# Altium schematic sheet COMMUNICATION.SchDoc
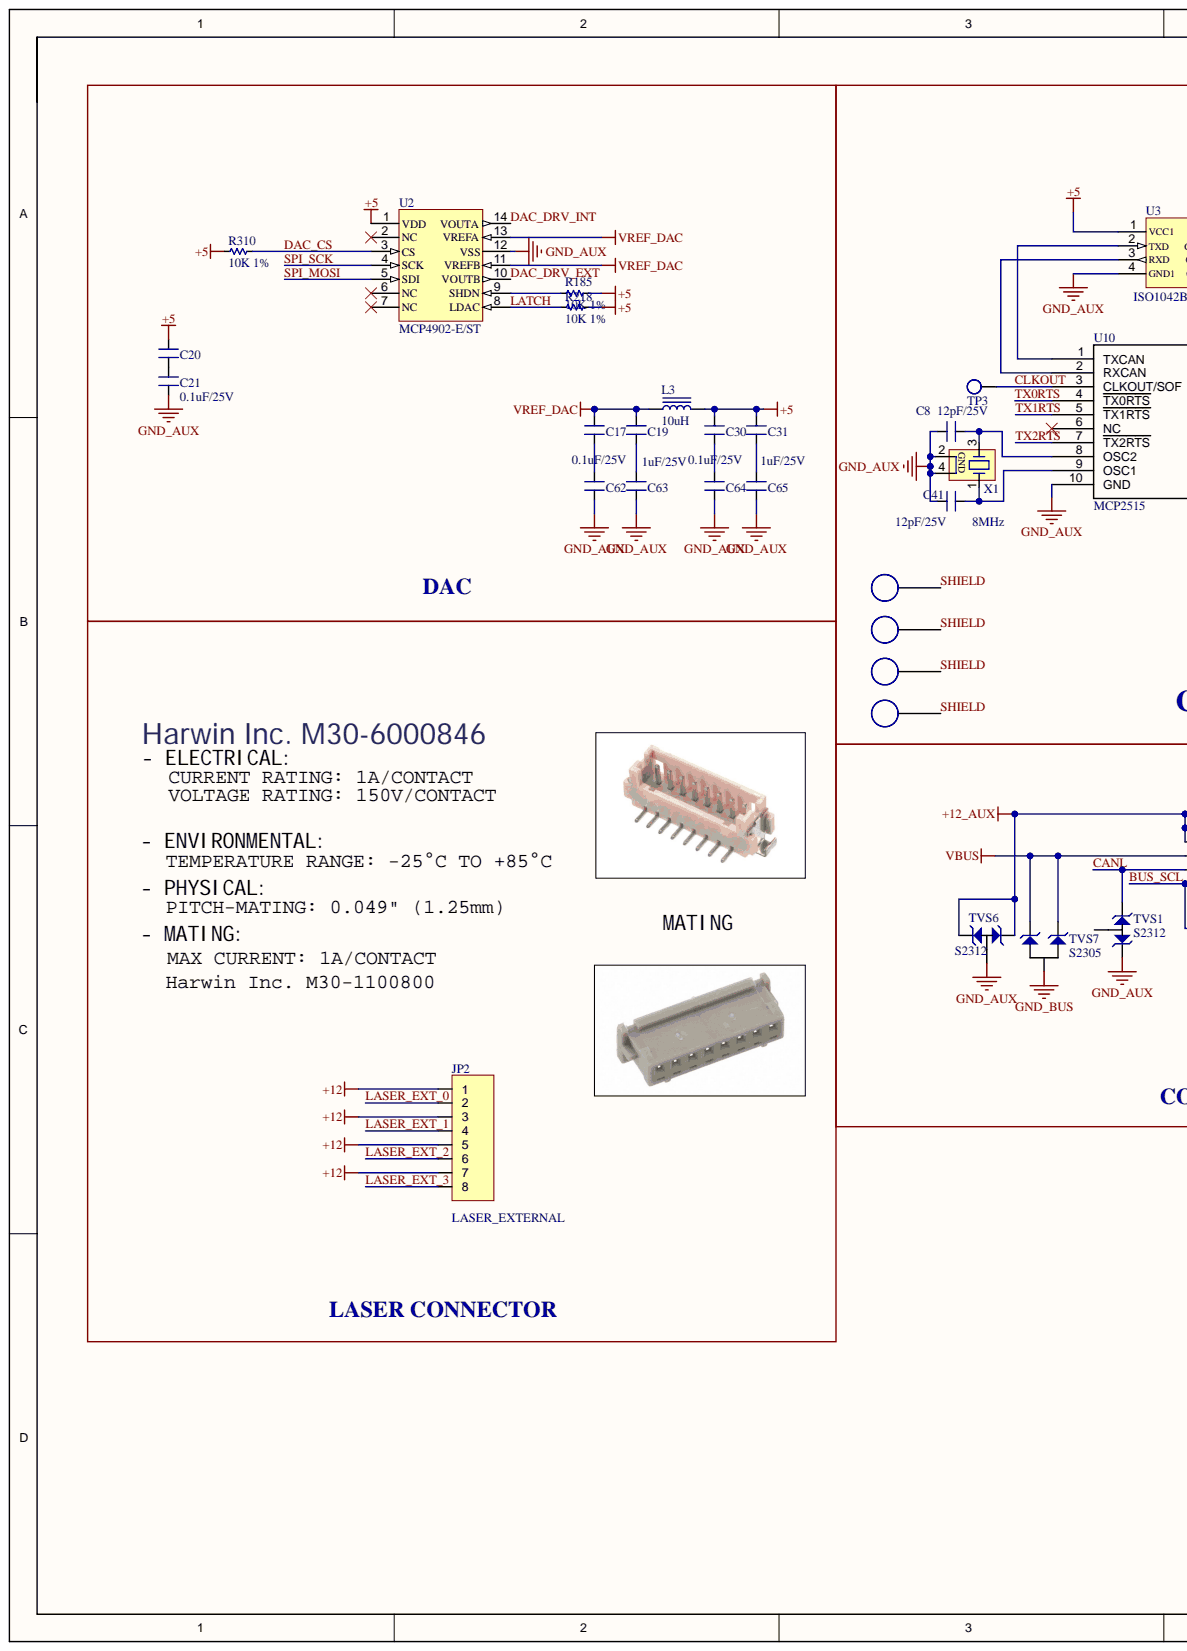
[diagram p0: COMMUNICATION.SchDoc, left]
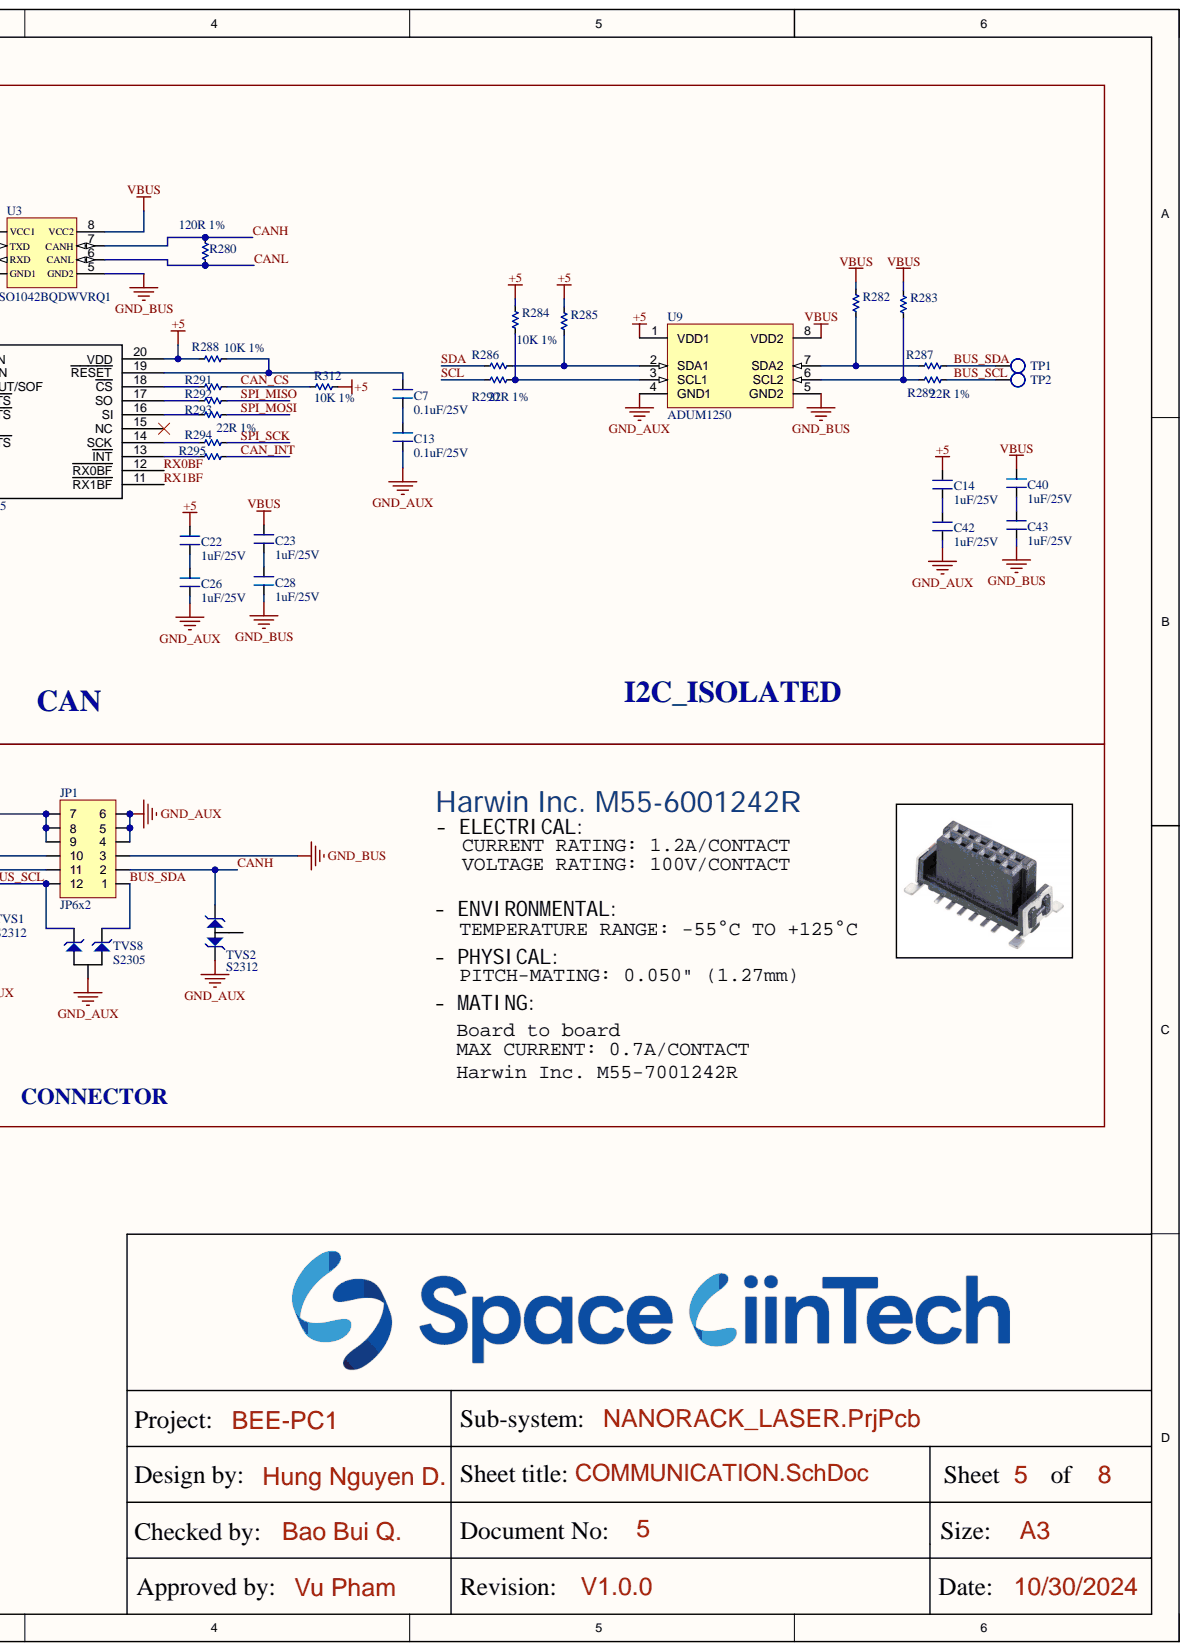
[diagram p1: COMMUNICATION.SchDoc, right]

## Parts
C7  0.1uF/25V  [SM-0603]
C8  12pF/25V  [SM-0603]
C13  0.1uF/25V  [SM-0603]
C14  1uF/25V  [SM-0603]
C17  0.1uF/25V  [SM-0603]
C19  1uF/25V  [SM-0603]
C20  0.1uF/25V  [SM-0603]
C21  0.1uF/25V  [SM-0603]
C22  1uF/25V  [SM-0603]
C23  1uF/25V  [SM-0603]
C26  1uF/25V  [SM-0603]
C28  1uF/25V  [SM-0603]
C30  0.1uF/25V  [SM-0603]
C31  1uF/25V  [SM-0603]
C40  1uF/25V  [SM-0603]
C41  12pF/25V  [SM-0603]
C42  1uF/25V  [SM-0603]
C43  1uF/25V  [SM-0603]
C62  0.1uF/25V  [SM-0603]
C63  1uF/25V  [SM-0603]
C64  0.1uF/25V  [SM-0603]
C65  1uF/25V  [SM-0603]
H1  M3  [M3]  (value not drawn)
H2  M3  [M3]  (value not drawn)
H3  M3  [M3]  (value not drawn)
H4  M3  [M3]  (value not drawn)
JP1  JP6x2  [M55-6001242R]
JP2  LASER_EXTERNAL  [JP8-C1C]
L3  10uH  [FER 0603/1608]
R185  10K 1%  [RES-SMD-0603]
R218  10K 1%  [RES-SMD-0603]
R280  120R 1%  [RES-SMD-0603]
R282  10K 1%  [RES-SMD-0603]  (value not drawn)
R283  10K 1%  [RES-SMD-0603]  (value not drawn)
R284  10K 1%  [RES-SMD-0603]
R285  10K 1%  [RES-SMD-0603]
R286  22R 1%  [RES-SMD-0603]
R287  22R 1%  [RES-SMD-0603]
R288  10K 1%  [RES-SMD-0603]
R289  22R 1%  [RES-SMD-0603]
R290  22R 1%  [RES-SMD-0603]
R291  22R 1%  [RES-SMD-0603]
R292  22R 1%  [RES-SMD-0603]
R293  22R 1%  [RES-SMD-0603]
R294  22R 1%  [RES-SMD-0603]
R295  22R 1%  [RES-SMD-0603]
R310  10K 1%  [RES-SMD-0603]
R312  10K 1%  [RES-SMD-0603]
TP1  Test-Point  [TESTPOINT]  (value not drawn)
TP2  Test-Point  [TESTPOINT]  (value not drawn)
TP3  Test-Point  [TESTPOINT]  (value not drawn)
TVS1  S2312  [SOT23-123]
TVS2  S2312  [SOT23-123]
TVS6  S2312  [SOT23-123]
TVS7  S2305  [SOT23-123]
TVS8  S2305  [SOT23-123]
U2  MCP4902-E/ST  [TSSOP14]
U3  ISO1042BQDWVRQ1  [SO8L]
U9  ADUM1250  [SO8]
U10  MCP2515  [TSSOP20]
X1  8MHz  [XT4-16.000MHz-4_V]

## Nets
+5: C14.1 C20.2 C22.1 C30.2 C31.2 L3.2 R185.1 R218.1 R284.1 R285.1 R288.1 R310.2 R312.2 U2.1(VDD) U3.1(VCC1) U9.1(VDD1) U10.20(VDD)
+12: JP2.1 JP2.3 JP2.5 JP2.7
+12_AUX: JP1.7 JP1.8 JP1.9 TVS6.1 TVS6.3
GND_AUX: C8.2 C13.2 C21.1 C26.2 C41.2 C42.2 C62.1 C63.1 C64.1 C65.1 JP1.4 JP1.5 JP1.6 TVS1.3 TVS2.3 TVS6.2 TVS8.2 U2.12(VSS) U3.4(GND1) U9.4(GND1) U10.10(GND) X1.2 X1.4
GND_BUS: C28.2 C43.2 JP1.3 TVS7.2 U3.5(GND2) U9.5(GND2)
VBUS: C23.1 C40.1 JP1.10 R282.1 R283.1 TVS7.1 TVS7.3 U3.8(VCC2) U9.8(VDD2)
VREF_DAC: C17.2 C19.2 L3.1 U2.11(VREFB) U2.13(VREFA)
BUS_SCL: JP1.12 R289.2 TP2.1 TVS8.1
BUS_SDA: JP1.1 R287.2 TP1.1 TVS8.3
CAN_CS: R291.2 R312.1
CAN_INT: R295.2
CANH: JP1.2 R280.1 TVS2.1 U3.7(CANH)
CANL: JP1.11 R280.2 TVS1.1 U3.6(CANL)
CLKOUT: TP3.1 U10.3(CLKOUT/SOF)
DAC_CS: R310.1 U2.3(CS)
DAC_DRV_EXT: U2.10(VOUTB)
DAC_DRV_INT: U2.14(VOUTA)
LASER_EXT_0: JP2.2
LASER_EXT_1: JP2.4
LASER_EXT_2: JP2.6
LASER_EXT_3: JP2.8
LATCH: R218.2 U2.8(LDAC)
RX0BF: U10.12(RX0BF)
RX1BF: U10.11(RX1BF)
SCL: R290.1
SDA: R286.1
SHIELD: H1.1 H2.1 H3.1 H4.1
SPI_MISO: R292.2
SPI_MOSI: R293.2 U2.5(SDI)
SPI_SCK: R294.2 U2.4(SCK)
TX0RTS: U10.4(TX0RTS)
TX1RTS: U10.5(TX1RTS)
TX2RTS: U10.7(TX2RTS)
NetC7_1: C7.1 R288.2 U10.19(RESET)
NetC7_2: C7.2 C13.1
NetC8_1: C8.1 U10.8(OSC2) X1.3
NetC14_2: C14.2 C42.1
NetC17_1: C17.1 C62.2
NetC19_1: C19.1 C63.2
NetC20_1: C20.1 C21.2
NetC22_2: C22.2 C26.1
NetC23_2: C23.2 C28.1
NetC30_1: C30.1 C64.2
NetC31_1: C31.1 C65.2
NetC40_2: C40.2 C43.1
NetC41_1: C41.1 U10.9(OSC1) X1.1
NetR185_2: R185.2 U2.9(SHDN)
NetR282_2: R282.2 R287.1 U9.7(SDA2)
NetR283_2: R283.2 R289.1 U9.6(SCL2)
NetR284_2: R284.2 R290.2 U9.3(SCL1)
NetR285_2: R285.2 R286.2 U9.2(SDA1)
NetR291_1: R291.1 U10.18(CS)
NetR292_1: R292.1 U10.17(SO)
NetR293_1: R293.1 U10.16(SI)
NetR294_1: R294.1 U10.14(SCK)
NetR295_1: R295.1 U10.13(INT)
NetU3_2: U3.2(TXD) U10.1(TXCAN)
NetU3_3: U3.3(RXD) U10.2(RXCAN)

Not connected: TVS1 2; TVS2 2; U2 2(NC) 6(NC) 7(NC); U10 6(NC) 15(NC)

## Library data of the parts
C7 C8 C13 C14 C17 C19-C23 C26 C28 C30 C31 C40-C43 C62-C65 = CM: 0603; Value: 103; Component Kind: Standard; Library Name: Capacitors.SchLib
JP1 = JP6x2: WR-PHD 2.54 mm Dual Socket Header; Manufacturer: W¨¹rth Elektronik eiSos GmbH; partNo: 61301221821; ComponentLink1 Description: Manufacturer; ComponentLink2 Description: Datasheet; NoPins: 12; Component Kind: Standard; ComponentLink1URL: http://www.we-online.com; ComponentLink2URL: http://katalog.we-online.de/em/datasheet/6130xx21821.pdf; Created Date: 29 March 2013; Library Name: Library.SchLib; Created by: Karrer Zheng
JP2 = JP8: WR-PHD 2.54 mm Angled Socket Header; Manufacturer: W¨¹rth Elektronik eiSos GmbH; partNo: 613008143121; ComponentLink1 Description: Manufacturer; ComponentLink2 Description: Datasheet; NoPins: 08; Component Kind: Standard; ComponentLink1URL: http://www.we-online.com; ComponentLink2URL: http://katalog.we-online.de/em/datasheet/6130xx143121.pdf; Created Date: 29 March 2013; Library Name: Library.SchLib; Created by: Karrer Zheng
L3 = Inductor: MLZ1608N100LT000; Value: 1.5uH; Component Kind: Standard; Library Name: INDUCTOR.SchLib
R185 R218 R280 R282-R295 R310 R312 = Res-SMD: 0603; Manufacturer: Generic Components; Class: Passive; Component Kind: Standard; Library Name: Library.SchLib; Published: 08/06/2000; Publisher: Altium Hobart Technology Centre
TP1-TP3 = Test-Point: DNP; Component Kind: Standard; Library Name: Library.SchLib
TVS1 TVS2 TVS6 = S2315: TVS DIODE 12VWM 19VC SOT23, P/N:  S2312; Component Kind: Standard; Library Name: Library.SchLib
TVS7 TVS8 = S2303: TVS DIODE 5VWM 10.8VC SOT23, P/N:    S2305; Component Kind: Standard; Library Name: Library.SchLib
U2 = MCP4902: IC DAC 8BIT V-OUT 14TSSOP; Component Kind: Standard; Library Name: Library.SchLib
U3 = ISO1042: IC TXRX/ISO FULL 1/1 8SOIC; Component Kind: Standard; Library Name: Library.SchLib
U10 = MCP2515: IC CAN CONTROLLER W/SPI 20TSSOP, P/N:    MCP2515-I/ST; Component Kind: Standard; Library Name: Library.SchLib
X1 = XT4: ECS-80-8-33Q-ADS; Value: 4Mhz; Component Kind: Standard; Library Name: Library.SchLib
H1-H4 = M3: Component Kind: Standard; Library Name: Library.SchLib
U9 = ADUM1250: Component Kind: Standard; Library Name: Library.SchLib
